# Altium schematic sheet Sheet2_v2.SchDoc (continued)

## Library data of the parts
C1 C7 C10 C11 C16 C19-C21 C35 C36 C38-C41 = C-EUC0402: CAPACITOR, European symbol; Component Kind: Standard; Library Name: rcl.IntLib
C2 C6 C18 = C-EUC0402: Component Kind: Standard; Library Name: rcl.IntLib
C3 C8 C9 C17 C25 = C-USC0805K: CAPACITOR, American symbol; Component Kind: Standard; Library Name: rcl.IntLib
C26 C29 = C-EUC0603K: CAPACITOR, European symbol; Component Kind: Standard; Library Name: rcl.IntLib
D1-D3 D5 = MBR0520LT: Component Kind: Standard; Library Name: _mod_diode.IntLib
D4 = MBR0520LT: SCHOTTKY BARRIER RECTIFIER   Source: http://onsemi.com .. MBR0520LT1-D.pdf; Component Kind: Standard; Library Name: _mod_diode.IntLib
I1 = R-EU_R0402: Inductor smd 0402; Component Kind: Standard; Library Name: rcl.IntLib
I2 I3 R1 R3 R4 R6-R21 R27-R32 R35 R36 R42 R46-R51 R54 R55 R63 R66 R67 R85 = R-EU_R0402: RESISTOR, European symbol; Component Kind: Standard; Library Name: rcl.IntLib
IC1 = STM32F4Z_144PINLQFP144FIXED: STM32F4XX LQFP packages with actual and functional symbols.; Component Kind: Standard; Library Name: stm32f407zet6.IntLib
IC2 = MOJ_BLEMOJ_BLE: CC2540-BLE: CC2540-BLE; Component Kind: Standard; Library Name: cc2540.IntLib
IC3 = MCP73831: Microchip's MCP73831 Miniature single cell, fully integrated Li-Ion, Li-polymer charge management controller http://ww1.microchip.com/downloads/en/DeviceDoc/21984a.pdf; Component Kind: Standard; Library Name: SparkFun.IntLib
IC4 IC5 IC15 = MCP1703CB: 250 mA, 16V, Low Quiescent Current LDO Regulator   Source: http://ww1.microchip.com/downloads/en/DeviceDoc/22049b.pdf; Component Kind: Standard; GND: GND; Library Name: linear.IntLib
IC10 = IS61WV51216BLL-*T: 512k x 16 high- speed CMOS static RAM   10ns access time 3.6V power supply; Component Kind: Standard; Library Name: memory-issi-asynsram.IntLib
K1 = MICROSD: Component Kind: Standard; Library Name: microbuilder.IntLib
K2 = USB"": Component Kind: Standard; Library Name: microusb.IntLib; USBAB: USBAB
K4 = N6300_LCD_CONN: Component Kind: Standard; Library Name: n6300lcd_conn.IntLib
L1 L2 = L-USL1812: INDUCTOR, American symbol; Component Kind: Standard; Library Name: rcl.IntLib
LED1 = LEDCHIPLED_0603: LED   OSRAM: - CHIPLED LG R971, LG N971, LY N971, LG Q971, LY Q971, LO R971, LY R971 LH N974, LH R974 LS Q976, LO Q976, LY Q976 LO Q996 - Hyper CHIPLED LW Q18S LB Q993, LB Q99A, LB R99A - SideLED LS A670, LO A670, LY A670, LG A670, LP A670 LB A673, LV A673, LT A673, LW A673 LH A674 LY A675 LS A676, LA A676, LO A676, LY A676, LW A676 LS A679, LY A679, LG A679 -  Hyper Micro SIDELED® LS Y876, LA Y876, LO Y876, LY Y876 LT Y87S - SmartLED LW L88C, LW L88S LB L89C, LB L89S, LG L890 LS L89K, LO L89K, LY L89K LS L896, LA L896, LO L896, LY L896 - TOPLED LS T670, LO T670, LY T670, LG T670, LP T670 LSG T670, LSP T670, LSY T670, LOP T670, LYG T670 LG T671, LOG T671, LSG T671 LB T673, LV T673, LT T673, LW T673 LH T674 LS T676, LA T676, LO T676, LY T676, LB T676, LH T676, LSB T676, LW T676 LB T67C, LV T67C, LT T67C, LS T67K, LO T67K, LY T67K, LW E67C LS E67B, LA E67B, LO E67B, LY E67B, LB E67C, LV E67C, LT E67C LW T67C LS T679, LY T679, LG T679 LS T770, LO T770, LY T770, LG T770, LP T770 LB T773, LV T773, LT T773, LW T773 LH T774 LS E675, LA E675, LY E675, LS T675 LS T776, LA T776, LO T776, LY T776, LB T776 LHGB T686 LT T68C, LB T68C - Hyper Mini TOPLED® LB M676 - Mini TOPLED Santana® LG M470 LS M47K, LO M47K, LY M47K    Source: http://www.osram.convergy.de   LUXEON: - LUMILED® LXK2-PW12-R00, LXK2-PW12-S00, LXK2-PW14-U00, LXK2-PW14-V00 LXK2-PM12-R00, LXK2-PM12-S00, LXK2-PM14-U00 LXK2-PE12-Q00, LXK2-PE12-R00, LXK2-PE12-S00, LXK2-PE14-T00, LXK2-PE14-U00 LXK2-PB12-K00, LXK2-PB12-L00, LXK2-PB12-M00, LXK2-PB14-N00, LXK2-PB14-P00, LXK2-PB14-Q00 LXK2-PR12-L00, LXK2-PR12-M00, LXK2-PR14-Q00, LXK2-PR14-R00 LXK2-PD12-Q00, LXK2-PD12-R00, LXK2-PD12-S00 LXK2-PH12-R00, LXK2-PH12-S00 LXK2-PL12-P00, LXK2-PL12-Q00, LXK2-PL12-R00    Source: www.luxeon.com   KINGBRIGHT:   KA-3528ASYC Source: www.kingbright.com; Component Kind: Standard; Library Name: led.IntLib
R2 R5 = R-EU_R0603: RESISTOR, European symbol; Component Kind: Standard; Library Name: rcl.IntLib
S1-S3 = SWITCHSMD: Component Kind: Standard; Library Name: SWITCHSMD.IntLib
T1-T4 = IRLML5203: HEXFET P-Channel Power MOSFET; Component Kind: Standard; D: D; G: G; Library Name: transistor-power.IntLib; S: S
T5 = MOSFET-NREFLOW: N-Channel Mosfet 2N7002E - 60V 260mA SOT23 [Digikey: 2N7002ET1GOSTR-ND] - REEL  BSH103 - 30V 850mA SOT23 [Digikey: 568-5013-1-ND]; Component Kind: Standard; D: D; G: G; Library Name: adafruit.IntLib; S: S
TP3 TP4 = TPTP20R: Component Kind: Standard; Library Name: testpad.IntLib
TP5-TP7 = WIREPADSMD1,27-254: Component Kind: Standard; Library Name: wirepad.IntLib
TP7-TP11 = PTR1B1,27: Component Kind: Standard; Library Name: testpad.IntLib
TP8-TP11 = SMD2: Component Kind: Standard; Library Name: wirepad.IntLib
TP12 TP13 = SMD5: Component Kind: Standard; Library Name: wirepad.IntLib
TP14 TP15 = TPTP08SQ: Test pad; Component Kind: Standard; Library Name: testpad.IntLib
X1 X2 = ASE: 3.3Vdc CMOS SMD CRYSTAL CLOCK OSCILLATOR   SOurce: www.abracon.com; Component Kind: Standard; GND: GND; Library Name: crystal-2.IntLib; OC_FARNELL: unknown; OC_NEWARK: unknown; OUT: OUT; TRI: TRI; VDD: VDD
X5 = CRYSTAL32.768: Component Kind: Standard; Library Name: crystal32.SCHLIB
IC6 = MCP1603T-330I/OS: Component Kind: Standard; Library Name: MCP1603T-330_v2.IntLib; MPN: MCP1603T-330I/OS; OC_FARNELL: 1439373; OC_NEWARK: 22K1332; PACKAGE: SOT23-5; SUPPLIER: Microchip
IC7 = HMC5883L: Component Kind: Standard; Library Name: hmc5883l.IntLib
IC8 = MPU-6050: Component Kind: Standard; Library Name: MPU-6050.IntLib
IC9 = AB0805: Component Kind: Standard; Library Name: ab0805.IntLib
IC11 = STMPE811: Component Kind: Standard; Library Name: stmpe811.IntLib
IC12 = AP5724: Component Kind: Standard; Library Name: ap5724_moj.SCHLIB
IC14 = VS1053: Component Kind: Standard; Library Name: welectronic.IntLib
K3 = MOJ_JACK: Component Kind: Standard; Library Name: moj_jack3.5_MIRROR.IntLib
